# Altium schematic sheet Quasar_ARM_схема.SchDoc
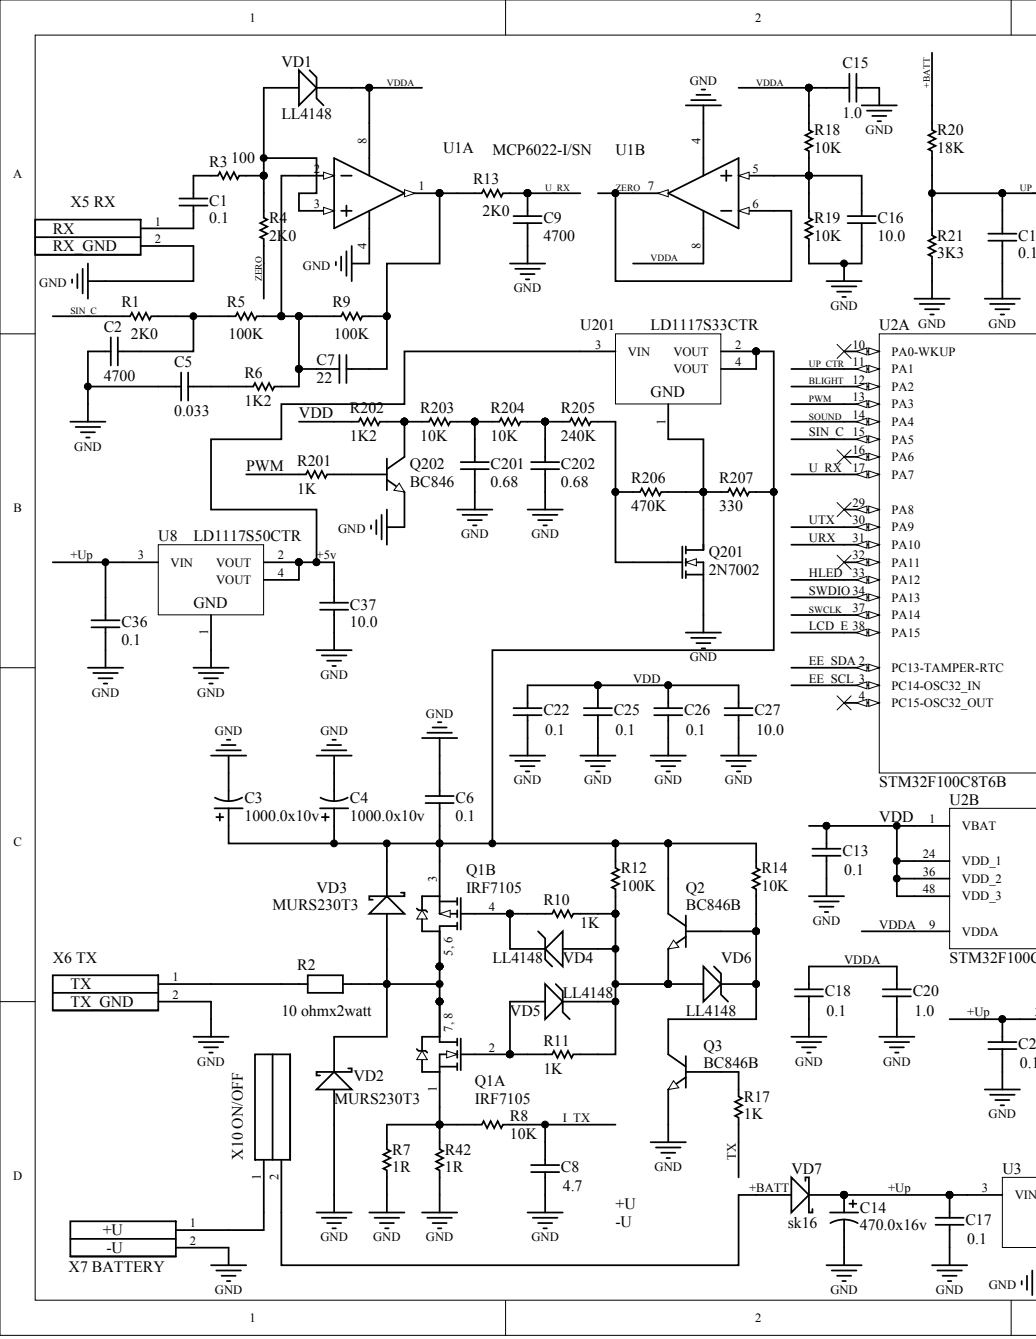
[diagram p0: Quasar_ARM_схема.SchDoc, left]
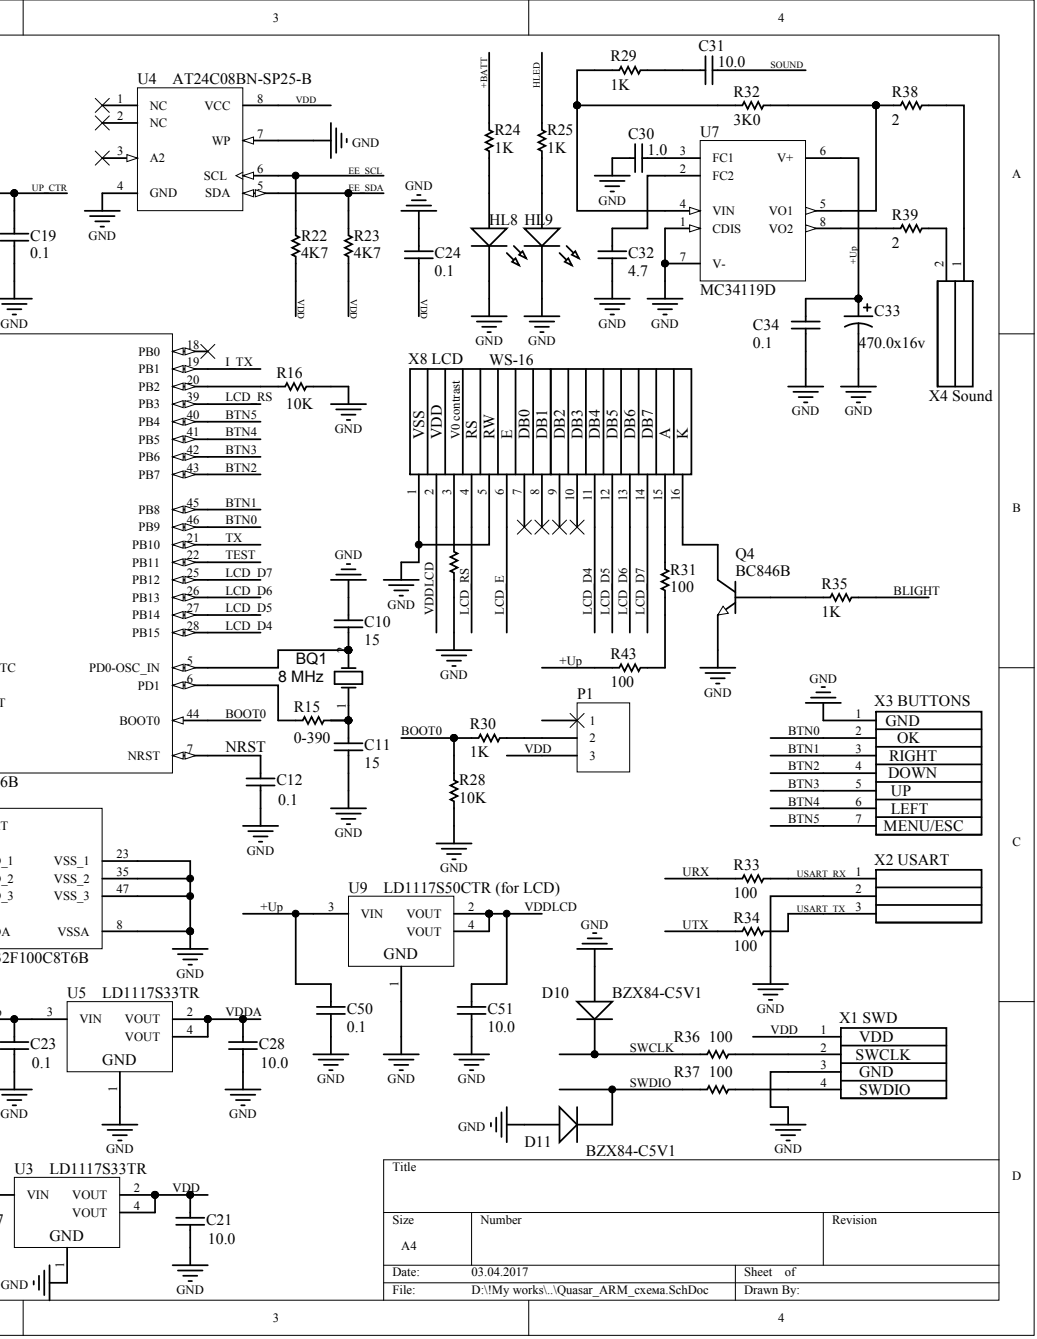
[diagram p1: Quasar_ARM_схема.SchDoc, right]

## Parts
BQ1  8 MHz
C1  value 0.1  (hidden comment: C1206)
C2  value 4700  (hidden comment: C1206)
C3  value 1000.0x10v  (hidden comment: T492D)
C4  value 1000.0x10v  (hidden comment: T492D)
C5  value 0.033  (hidden comment: C1206)
C6  value 0.1  (hidden comment: C1206)
C7  value 22  (hidden comment: C1206)
C8  value 4.7  (hidden comment: C1206)
C9  value 4700  (hidden comment: C1206)
C10  value 15  (hidden comment: C1206)
C11  value 15  (hidden comment: C1206)
C12  value 0.1  (hidden comment: C1206)
C13  value 0.1  (hidden comment: C1206)
C14  value 470.0x16v  (hidden comment: T492D)
C15  value 1.0  (hidden comment: C1206)
C16  value 10.0  (hidden comment: C1206)
C17  value 0.1  (hidden comment: C1206)
C18  value 0.1  (hidden comment: C1206)
C19  value 0.1  (hidden comment: C1206)
C20  value 1.0  (hidden comment: C1206)
C21  value 10.0  (hidden comment: C1206)
C22  value 0.1  (hidden comment: C1206)
C23  value 0.1  (hidden comment: C1206)
C24  value 0.1  (hidden comment: C1206)
C25  value 0.1  (hidden comment: C1206)
C26  value 0.1  (hidden comment: C1206)
C27  value 10.0  (hidden comment: C1206)
C28  value 10.0  (hidden comment: C1206)
C30  value 1.0  (hidden comment: C1206)
C31  value 10.0  (hidden comment: C1206)
C32  value 4.7  (hidden comment: C1206)
C33  value 470.0x16v  (hidden comment: T492D)
C34  value 0.1  (hidden comment: C1206)
C36  value 0.1  (hidden comment: C1206)
C37  value 10.0  (hidden comment: C1206)
C50  value 0.1  (hidden comment: C1206)
C51  value 10.0  (hidden comment: C1206)
C201  value 0.68  (hidden comment: C1206)
C202  value 0.68  (hidden comment: C1206)
D10  BZX84-C5V1
D11  BZX84-C5V1
HL8  S1206YGSC  (value not drawn)
HL9  S1206YGSC  (value not drawn)
P1  Header 3  (value not drawn)
Q1  IRF7105  (parts A,B)
Q2  BC846B
Q3  BC846B
Q4  BC846B
Q201  2N7002
Q202  BC846
R1  value 2K0  (hidden comment: ERJ8EN)
R2  value 10 ohmx2watt  (hidden comment: Resistor)
R3  value 100  (hidden comment: ERJ8EN)
R4  value 2K0  (hidden comment: ERJ8EN)
R5  value 100K  (hidden comment: ERJ8EN)
R6  value 1K2  (hidden comment: ERJ8EN)
R7  value 1R  (hidden comment: ERJ8EN)
R8  value 10K  (hidden comment: ERJ8EN)
R9  value 100K  (hidden comment: ERJ8EN)
R10  value 1K  (hidden comment: ERJ8EN)
R11  value 1K  (hidden comment: ERJ8EN)
R12  value 100K  (hidden comment: ERJ8EN)
R13  value 2K0  (hidden comment: ERJ8EN)
R14  value 10K  (hidden comment: ERJ8EN)
R15  value 0-390  (hidden comment: ERJ8EN)
R16  value 10K  (hidden comment: ERJ8EN)
R17  value 1K  (hidden comment: ERJ8EN)
R18  value 10K  (hidden comment: ERJ8EN)
R19  value 10K  (hidden comment: ERJ8EN)
R20  value 18K  (hidden comment: ERJ8EN)
R21  value 3K3  (hidden comment: ERJ8EN)
R22  value 4K7  (hidden comment: ERJ8EN)
R23  value 4K7  (hidden comment: ERJ8EN)
R24  value 1K  (hidden comment: ERJ8EN)
R25  value 1K  (hidden comment: ERJ8EN)
R27!!!!!!!!!!  ERJ8EN  (value not drawn)
R28  value 10K  (hidden comment: ERJ8EN)
R29  value 1K  (hidden comment: ERJ8EN)
R30  value 1K  (hidden comment: ERJ8EN)
R31  value 100  (hidden comment: ERJ8EN)
R32  value 3K0  (hidden comment: ERJ8EN)
R33  value 100  (hidden comment: ERJ8EN)
R34  value 100  (hidden comment: ERJ8EN)
R35  value 1K  (hidden comment: ERJ8EN)
R36  value 100  (hidden comment: ERJ8EN)
R37  value 100  (hidden comment: ERJ8EN)
R38  value 2  (hidden comment: ERJ8EN)
R39  value 2  (hidden comment: ERJ8EN)
R42  value 1R  (hidden comment: ERJ8EN)
R43  value 100  (hidden comment: ERJ8EN)
R201  value 1K  (hidden comment: ERJ8EN)
R202  value 1K2  (hidden comment: ERJ8EN)
R203  value 10K  (hidden comment: ERJ8EN)
R204  value 10K  (hidden comment: ERJ8EN)
R205  value 240K  (hidden comment: ERJ8EN)
R206  value 470K  (hidden comment: ERJ8EN)
R207  value 330  (hidden comment: ERJ8EN)
U1  MCP6022-I/SN  (parts A,B)
U2  STM32F100C8T6B  (parts A,B)
U3  LD1117S33TR
U4  AT24C08BN-SP25-B
U5  LD1117S33TR
U7  MC34119D
U8  LD1117S50CTR
U9  LD1117S50CTR (for LCD)  PartNumber: LD1117S50CTR
U201  LD1117S33CTR
VD1  LL4148
VD2  MURS230T3
VD3  MURS230T3
VD4  LL4148
VD5  LL4148
VD6  LL4148
VD7  sk16
X1_SWD  WS-4  (value not drawn)
X2_USART  ws-3  (value not drawn)
X3_BUTTONS  WS-7  (value not drawn)
X4_Sound  WS-2  (value not drawn)
X5_RX  WS-2  (value not drawn)
X6_TX  WS-2  (value not drawn)
X7_BATTERY  WS-2  (value not drawn)
X8_LCD  WS-16
X10_ON/OFF  WS-2  (value not drawn)

## Nets
GND: C2.1 C3.2 C4.2 C5.2 C6.2 C8.1 C9.2 C10.2 C11.1 C12.1 C13.1 C14.2 C15.2 C16.2 C17.1 C18.1 C19.2 C20.1 C21.1 C22.1 C23.1 C24.1 C25.1 C26.1 C27.1 C28.1 C30.1 C32.1 C33.2 C34.2 C36.2 C37.2 C50.2 C51.2 C201.1 C202.1 D10.1 D11.1 HL8.2 HL9.2 Q3.2 Q4.2 Q201.2 Q202.2 R7.2 R16.2 R19.1 R21.1 R27!!!!!!!!!!.1 R28.1 R42.2 U1.4 U2.8(VSSA) U2.23(VSS_1) U2.35(VSS_2) U2.47(VSS_3) U3.1 U4.4(GND) U4.7(WP) U5.1 U7.1(CDIS) U7.7(V-) U8.1 U9.1 VD2.1 X1_SWD.3(GND) X2_USART.2 X3_BUTTONS.1(GND) X5_RX.2 X6_TX.2 X7_BATTERY.2 X8_LCD.1 X8_LCD.5
+5V: C37.1 U8.2(VOUT) U8.4(VOUT) U201.3(VIN)
+BATT: R20.2 R24.2 VD7.1 X10_ON/OFF.2
+UP: C14.1 C17.2 C23.2 C33.1 C34.1 C36.1 C50.1 R43.2 U3.3(VIN) U5.3(VIN) U7.6(V+) U8.3(VIN) U9.3(VIN) VD7.2
BLIGHT: R35.1 U2.12(PA2)
BOOT0: R28.2 R30.2 U2.44(BOOT0)
BTN0: U2.46(PB9) X3_BUTTONS.2(OK)
BTN1: U2.45(PB8) X3_BUTTONS.3(RIGHT)
BTN2: U2.43(PB7) X3_BUTTONS.4(DOWN)
BTN3: U2.42(PB6) X3_BUTTONS.5(UP)
BTN4: U2.41(PB5) X3_BUTTONS.6(LEFT)
BTN5: U2.40(PB4) X3_BUTTONS.7(MENU/ESC)
EE_SCL: R22.2 U2.3(PC14-OSC32_IN) U4.6(SCL)
EE_SDA: R23.2 U2.2(PC13-TAMPER-RTC) U4.5(SDA)
HLED: R25.2 U2.33(PA12)
I_TX: C8.2 R8.2 U2.19(PB1)
LCD_D4: U2.28(PB15) X8_LCD.11
LCD_D5: U2.27(PB14) X8_LCD.12
LCD_D6: U2.26(PB13) X8_LCD.13
LCD_D7: U2.25(PB12) X8_LCD.14
LCD_E: U2.38(PA15) X8_LCD.6
LCD_RS: U2.39(PB3) X8_LCD.4
NRST: C12.2 U2.7(NRST)
PWM: R201.2 U2.13(PA3)
SIN_C: R1.1 U2.15(PA5)
SOUND: C31.2 U2.14(PA4)
SWCLK: D10.3 R36.2 U2.37(PA14)
SWDIO: D11.3 R37.2 U2.34(PA13)
TEST: U2.22(PB11)
TX: R17.1 U2.21(PB10)
U_RX: C9.1 R13.2 U2.17(PA7)
UP_CTR: C19.1 R20.1 R21.2 U2.11(PA1)
URX: R33.2 U2.31(PA10)
USART_RX: R33.1 X2_USART.1
USART_TX: R34.1 X2_USART.3
UTX: R34.2 U2.30(PA9)
VDD: C13.2 C21.2 C22.2 C24.2 C25.2 C26.2 C27.2 P1.3 R22.1 R23.1 R202.2 U2.1(VBAT) U2.24(VDD_1) U2.36(VDD_2) U2.48(VDD_3) U3.2(VOUT) U3.4(VOUT) U4.8(VCC) X1_SWD.1(VDD)
VDDA: C15.1 C18.2 C20.2 C28.2 R18.2 U1.8 U2.9(VDDA) U5.2(VOUT) U5.4(VOUT) VD1.2
VDDLCD: C51.1 U9.2(VOUT) U9.4(VOUT) X8_LCD.2
ZERO: R4.1 U1.6 U1.7
NetBQ1_1: BQ1.1 C11.2 R15.2
NetBQ1_2: BQ1.2 C10.1 U2.5(PD0-OSC_IN)
NetC1_1: C1.1 X5_RX.1
NetC1_2: C1.2 R3.1
NetC2_2: C2.2 R1.2 R5.1
NetC3_1: C3.1 C4.1 C6.1 Q1.3 Q2.3 R12.2 R14.2 R207.2 U201.2(VOUT) U201.4(VOUT) VD3.2
NetC5_1: C5.1 R6.2
NetC7_1: C7.1 R5.2 R6.1 R9.1 U1.2
NetC7_2: C7.2 R9.2 R13.1 U1.1
NetC16_1: C16.1 R18.1 R19.2 U1.5
NetC30_2: C30.2 U7.3(FC1)
NetC31_1: C31.1 R29.1
NetC32_2: C32.2 U7.2(FC2)
NetC201_2: C201.2 R203.1 R204.2
NetC202_2: C202.2 R204.1 R205.2
NetHL8_1: HL8.1 R24.1
NetHL9_1: HL9.1 R25.1
NetP1_2: P1.2 R30.1
NetQ1_1: Q1.1 R7.1 R8.1 R42.1
NetQ1_2: Q1.2 R11.1 VD5.1
NetQ1_4: Q1.4 R10.1 VD4.2
NetQ1_5: Q1.5 Q1.6 Q1.7 Q1.8 R2.2 VD2.2 VD3.1
NetQ2_1: Q2.1 Q3.3 R14.1 VD6.2
NetQ2_2: Q2.2 R10.2 R11.2 R12.1 VD4.1 VD5.2 VD6.1
NetQ3_1: Q3.1 R17.2
NetQ4_1: Q4.1 R35.2
NetQ4_3: Q4.3 X8_LCD.16
NetQ201_1: Q201.1 R206.2 R207.1 U201.1
NetQ201_3: Q201.3 R205.1 R206.1
NetQ202_1: Q202.1 R201.1
NetQ202_3: Q202.3 R202.1 R203.2
NetR2_1: R2.1 X6_TX.1
NetR3_2: R3.2 R4.2 U1.3 VD1.1
NetR15_1: R15.1 U2.6(PD1)
NetR16_1: R16.1 U2.20(PB2)
NetR27!!!!!!!!!!_2: R27!!!!!!!!!!.2 X8_LCD.3
NetR29_2: R29.2 R32.2 U7.4(VIN)
NetR31_1: R31.1 R43.1
NetR31_2: R31.2 X8_LCD.15
NetR32_1: R32.1 R38.2 U7.5(VO1)
NetR36_1: R36.1 X1_SWD.2(SWCLK)
NetR37_1: R37.1 X1_SWD.4(SWDIO)
NetR38_1: R38.1 X4_Sound.1
NetR39_1: R39.1 X4_Sound.2
NetR39_2: R39.2 U7.8(VO2)
NetX7 BATTERY_1: X7_BATTERY.1 X10_ON/OFF.1

Not connected: P1 1; U2 4(PC15-OSC32_OUT) 10(PA0-WKUP) 16(PA6) 18(PB0) 29(PA8) 32(PA11); U4 1(NC) 2(NC) 3(A2); X8_LCD 7 8 9 10

## Library data of the parts
D10 D11 = (no symbol name): Note: Pin 2 is not connected and not drawn; Published: 17-Sep-2003; LatestRevisionDate: 12-Dec-2006; LatestRevisionNote: IPC-7351 Footprint Added.; DatasheetVersion: 18-May-1999; PackageVersion: May-1999; PackageReference: SOT23; PackageDescription: 3-Pin SOT-23 Package 0.95 mm Pitch, 2.3 mm Lead Span; ComponentLink1Description: Manufacturer Link; ComponentLink1URL: http://www.semiconductors.philips.com/; ComponentLink2Description: Datasheet; ComponentLink2URL: http://www.semiconductors.philips.com/acrobat/datasheets/BZX84_SERIES_3.pdf; Publisher: Altium Limited
R1 R13 = (no symbol name): Value: 2K0; Published: 17-Dec-2003; LatestRevisionDate: 19-Mar-2004; LatestRevisionNote: Silkscreen size reduced.; PackageDocument: Mar-2003; PackageReference: 8-1206; Code_IPC: 3216 [1206]; ComponentLink1Description: Manufacturer Link; ComponentLink1URL: http://www.panasonic.com/industrial/components/resistive_products.htm; ComponentLink2Description: Datasheet; ComponentLink2URL: http://www.panasonic.com/industrial/components/pdf/AOA0000CE2.pdf; Publisher: Altium Limited
R27!!!!!!!!!! = (no symbol name): Value: 10K contrast; Published: 17-Dec-2003; LatestRevisionDate: 19-Mar-2004; LatestRevisionNote: Silkscreen size reduced.; PackageDocument: Mar-2003; PackageReference: 8-1206; Code_IPC: 3216 [1206]; ComponentLink1Description: Manufacturer Link; ComponentLink1URL: http://www.panasonic.com/industrial/components/resistive_products.htm; ComponentLink2Description: Datasheet; ComponentLink2URL: http://www.panasonic.com/industrial/components/pdf/AOA0000CE2.pdf; Publisher: Altium Limited
U201 = (no symbol name): PartNumber: LD1117S50CTR; DatasheetVersion: Nov-2011; PackageVersion: 2010; PackageReference: SOT-223; PackageDescription: 4-Pin SOT-223 Package, 6.5 x 3.5 mm Body, 2.3 mm Pitch, 7 mm Lead Span; ComponentLink1Description: Manufacturer URL; ComponentLink1URL: http://www.st.com; ComponentLink2Description: Datasheet; ComponentLink2URL: http://www.st.com/internet/com/TECHNICAL_RESOURCES/TECHNICAL_LITERATURE/DATASHEET/CD00000544.pdf
BQ1 C1-C28 C30-C34 C36 C37 C50 C51 C201 C202 HL8 HL9 P1 Q1-Q4 Q201 Q202 R2-R12 R14-R25 R28-R39 R42 R43 R201-R207 U1-U5 U7-U9 VD1-VD7 X1_SWD X2_USART X3_BUTTONS X4_Sound X5_RX X6_TX X7_BATTERY X8_LCD X10_ON/OFF = (no symbol name)
  BQ1: Published: 8-Jun-2000; LatestRevisionDate: 17-Jul-2002; LatestRevisionNote: Re-released for DXP Platform.; PackageReference: R38
  C1 C2 C5-C13 C15-C28 C30-C32 C34 C36 C37 C50 C51 C201 C202: Published: 17-Jul-2002; LatestRevisionDate: 19-Mar-2004; LatestRevisionNote: Silkscreen size reduced.; DatasheetDocument: Mar-2001; PackageDocument: Mar-2001; PackageReference: 1206; Code_JEITA: 1206; Code_IPC: 3216 [1206]; ComponentLink1Description: Manufacturer Link; ComponentLink1URL: http://www.kemet.com/; ComponentLink2Description: Datasheet; ComponentLink2URL: http://www.kemet.com/kemet/web/homepage/kechome.nsf/vapubfilesname/F3102TA.pdf/$file/F3102TA.pdf
  C3 C4 C14 C33: Published: 17-Jul-2002; LatestRevisionDate: 19-Mar-2004; LatestRevisionNote: Silkscreen dimensions re-sized.; DatasheetDocument: Mar-2001; PackageDocument: Mar-2001; PackageReference: D; Code_JEITA: 7343-31; ComponentLink1Description: Manufacturer Link; ComponentLink1URL: http://www.kemet.com/; ComponentLink2Description: Datasheet; ComponentLink2URL: http://www.kemet.com/kemet/web/homepage/kechome.nsf/vapubfilesname/F3102TA.pdf/$file/F3102TA.pdf
  HL8 HL9: Published: 4-Feb-2010; PackageReference: SMD 1206; магазин: http://chip-nn.ru/67.php; Datasheet: http://chip-nn.ru/doc/led/s1206ygsc/s1206ygsc.zip; Publisher: Сергеев
  P1: LatestRevisionDate: 17-Jul-2002; LatestRevisionNote: Re-released for DXP Platform.
  Q1: Published: 8-Jun-2000; LatestRevisionDate: 13-Apr-2006; LatestRevisionNote: IPC-7351 Footprint Added.; DatasheetVersion: Nov-1997; PackageVersion: Feb-2001; PackageReference: SO-8; PackageDescription: 8-Pin Plastic Small Outline Integrated Circuit 1.27 mm Pitch; Code_JEDEC: MS-012-AA; Code_IPC: SOIC127P600-8; ComponentLink1Description: Manufacturer Link; ComponentLink1URL: http://www.irf.com/; ComponentLink2Description: Datasheet; ComponentLink2URL: http://www.irf.com/product-info/datasheets/data/irf7105.pdf
  Q2-Q4 Q202: Published: 17-Sep-2003; LatestRevisionDate: 12-Dec-2006; LatestRevisionNote: IPC-7351 Footprint Added.; DatasheetVersion: 4-Feb-2002; PackageVersion: May-1999; PackageReference: SOT23; PackageDescription: 3-Pin SOT-23 Package 0.95 mm Pitch, 2.3 mm Lead Span; ComponentLink1Description: Manufacturer Link; ComponentLink1URL: http://www.semiconductors.philips.com/; ComponentLink2Description: Datasheet; ComponentLink2URL: http://www.semiconductors.philips.com/acrobat/datasheets/BC846_BC847_BC848_5.pdf
  Q201: Published: 8-Jun-2000; LatestRevisionDate: 17-Jul-2002; LatestRevisionNote: Re-released for DXP Platform.; DatasheetVersion: Jan-1996; PackageVersion: 1996; PackageReference: SOT23; PackageDescription: SOT-23; 3 Leads; Body 3.1 x 2.5 mm, inc. leads (LxW max), Alternate Lead Configuration; ComponentLink1Description: Manufacturer Link; ComponentLink1URL: http://www.zetex.com/
  R2: Type: Resistor
  R3-R12 R14-R25 R28-R39 R42 R43 R201-R207: Published: 17-Dec-2003; LatestRevisionDate: 19-Mar-2004; LatestRevisionNote: Silkscreen size reduced.; PackageDocument: Mar-2003; PackageReference: 8-1206; Code_IPC: 3216 [1206]; ComponentLink1Description: Manufacturer Link; ComponentLink1URL: http://www.panasonic.com/industrial/components/resistive_products.htm; ComponentLink2Description: Datasheet; ComponentLink2URL: http://www.panasonic.com/industrial/components/pdf/AOA0000CE2.pdf
  U1: Published: 29-Jan-2009; LatestRevisionDate: 02-Dec-2009; LatestRevisionNote: Updated to datasheet revision D, Feb-2009; DatasheetVersion: revD, Feb-2009; PackageVersion: revBB, Aug-2009; PackageReference: SOIC-SN8; PackageDescription: 8-Lead Plastic Small Outline (SN) - Narrow, 3.90mm Body [SOIC]; Supplier 1: Digi-Key; Supplier Part Number 1: MCP6022-I/SN-ND; Supplier 2: Farnell; Supplier Part Number 2: 1439415; Code_JEDEC: MS-012; Code_IPC: SOIC127P600-8; ComponentLink1Description: Datasheet; ComponentLink1URL: http://ww1.microchip.com/downloads/en/DeviceDoc/21685d.pdf; ComponentLink2Description: Packaging Specification; ComponentLink2URL: http://www.microchip.com/stellent/groups/techpub_sg/documents/packagingspec/en012702.pdf; ComponentLink3Description: Microchip.com Home; ComponentLink3URL: http://www.microchip.com/
  U2: DatasheetVersion: rev6.0, Apr-2011; PackageVersion: rev13, Apr-2011; PackageReference: LQFP48; PackageDescription: 48-Pin Low-Profile Quad Flat Pack, 7 x 7 mm Body, 0.5 mm Pitch (LQFP48); ComponentLink1Description: Manufacturer URL; ComponentLink1URL: http://www.st.com/; ComponentLink2Description: STM32F100 Datasheet; ComponentLink2URL: http://www.st.com/internet/com/TECHNICAL_RESOURCES/TECHNICAL_LITERATURE/DATASHEET/CD00251732.pdf; ComponentLink3Description: STM32 Family Web Page; ComponentLink3URL: www.st.com/stm32
  U3 U5: DatasheetVersion: Nov-2011; PackageVersion: 2010; PackageReference: SOT-223; PackageDescription: 4-Pin SOT-223 Package, 6.5 x 3.5 mm Body, 2.3 mm Pitch, 7 mm Lead Span; PartNumber: LD1117S33TR; ComponentLink1Description: Manufacturer URL; ComponentLink1URL: http://www.st.com; ComponentLink2Description: Datasheet; ComponentLink2URL: http://www.st.com/internet/com/TECHNICAL_RESOURCES/TECHNICAL_LITERATURE/DATASHEET/CD00000544.pdf
  U4: Published: 20-Sep-2010; DatasheetVersion: 8517C,  Jan-2009; PackageVersion: revC, Mar-2005; PackageReference: 8S1; PackageDescription: 8-Lead (0.150" Wide Body), Plastic Gull Wing Small Outline (JEDEC SOIC); Code_JEDEC: MS-012-AA; Code_IPC: SOIC127P600-8; ComponentLink1Description: Manufacturer Link; ComponentLink1URL: http://www.atmel.com/products/serial/default.asp; ComponentLink2Description: Datasheet; ComponentLink2URL: http://www.atmel.com/dyn/resources/prod_documents/doc8517.pdf; ComponentLink3Description: Atmel.com Home; ComponentLink3URL: http://www.atmel.com
  U7: Published: 17-Jul-2002; LatestRevisionDate: 13-Apr-2006; LatestRevisionNote: IPC-7351 Footprint Added.; DatasheetVersion: 1989; PackageReference: 751-03; PackageDescription: 8-Pin Small Outline Integrated Circuit 1.27 mm Pitch; Code_JEDEC: MS-012-AA; Code_IPC: SOIC127P600-8
  U8: DatasheetVersion: Nov-2011; PackageVersion: 2010; PackageReference: SOT-223; PackageDescription: 4-Pin SOT-223 Package, 6.5 x 3.5 mm Body, 2.3 mm Pitch, 7 mm Lead Span; PartNumber: LD1117S50CTR; ComponentLink1Description: Manufacturer URL; ComponentLink1URL: http://www.st.com; ComponentLink2Description: Datasheet; ComponentLink2URL: http://www.st.com/internet/com/TECHNICAL_RESOURCES/TECHNICAL_LITERATURE/DATASHEET/CD00000544.pdf
  U9: DatasheetVersion: Nov-2011; PackageVersion: 2010; PackageReference: SOT-223; PackageDescription: 4-Pin SOT-223 Package, 6.5 x 3.5 mm Body, 2.3 mm Pitch, 7 mm Lead Span; ComponentLink1Description: Manufacturer URL; ComponentLink1URL: http://www.st.com; ComponentLink2Description: Datasheet; ComponentLink2URL: http://www.st.com/internet/com/TECHNICAL_RESOURCES/TECHNICAL_LITERATURE/DATASHEET/CD00000544.pdf
  VD2 VD3: Published: 8-Jun-2000; LatestRevisionDate: 17-Jul-2002; LatestRevisionNote: Re-released for DXP Platform.; DatasheetVersion: PD-2.490; PackageReference: SMC; PackageDescription: DO-214-AB/SMC; 2 C-Bend Leads; Body 7.9 x 5.9 mm, inc. leads (LxW); Code_JEDEC: DO-214-AB; ComponentLink1Description: Manufacturer Link; ComponentLink1URL: http://www.irf.com/; ComponentLink2Description: Datasheet; ComponentLink2URL: http://www.irf.com/product-info/datasheets/data/30bq015.pdf
  VD7: Published: 17-Sep-2003; DatasheetVersion: May-2002; PackageVersion: revH, May-2002; PackageReference: SMA; PackageDescription: DO-214-AC/SMA; 2 C-Bend Leads; Body 5 x 2.7 mm, inc. leads (LxW); ComponentLink1Description: Manufacturer Link; ComponentLink1URL: http://www.irf.com/; ComponentLink2Description: Datasheet; ComponentLink2URL: http://www.irf.com/product-info/datasheets/data/10MQ060N.pdf
  BQ1 C1-C28 C30-C34 C36 C37 C50 C51 C201 C202 P1 Q1-Q4 Q201 Q202 R3-R12 R14-R25 R28-R39 R42 R43 R201-R207 U1 U4 U7 VD2 VD3 VD7: Publisher: Altium Limited
